# Altium schematic sheet Discrete.SchDoc
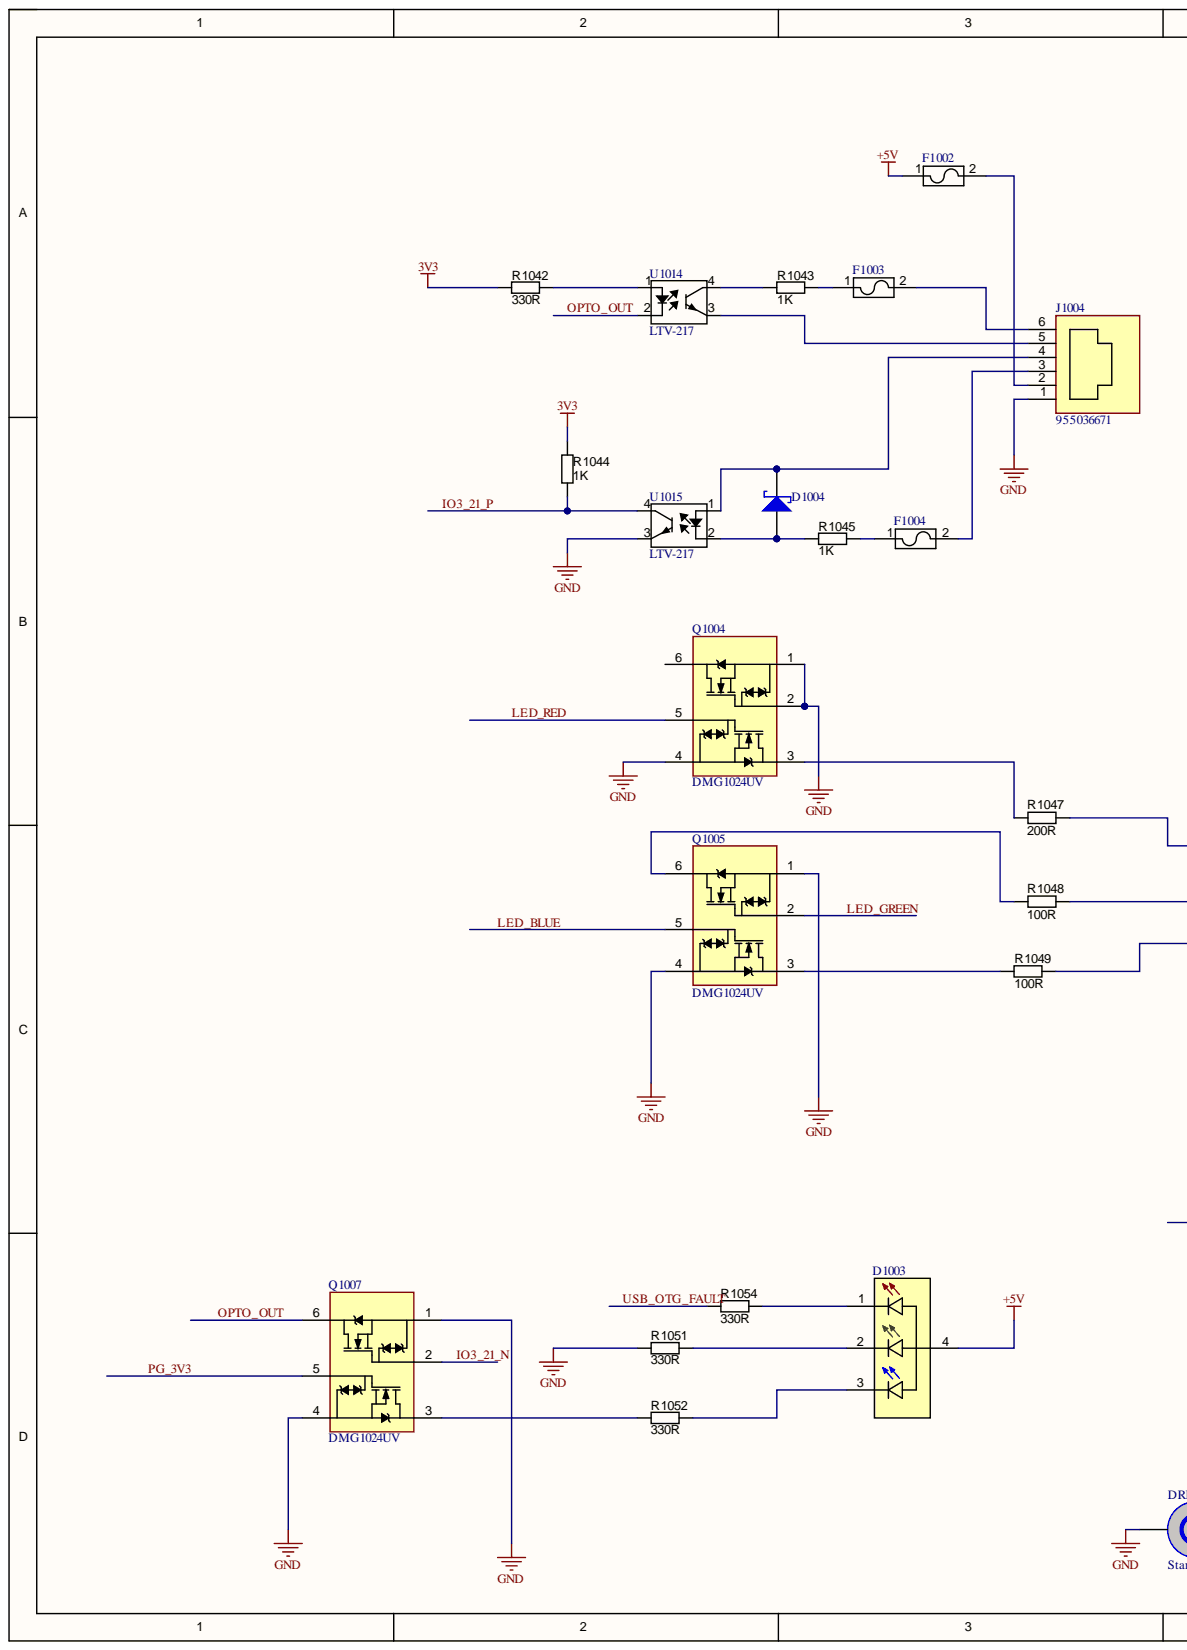
[diagram p0: Discrete.SchDoc, left]
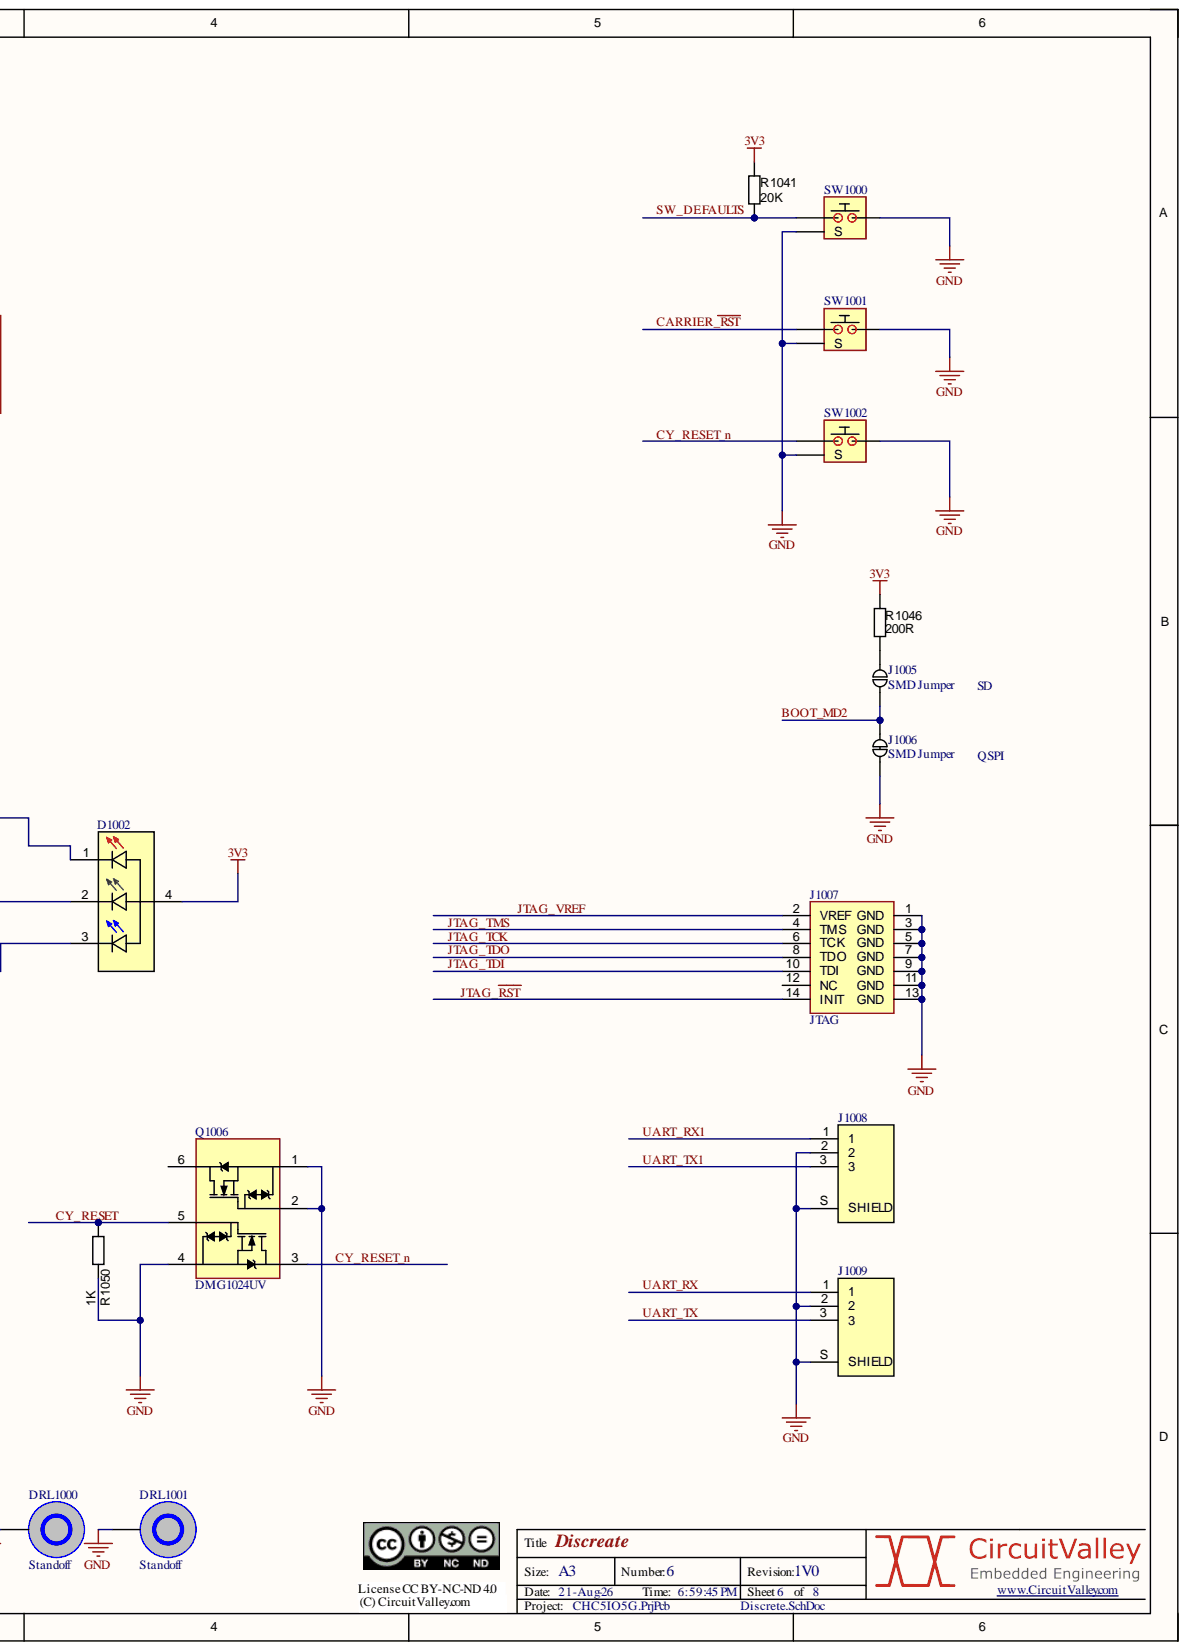
[diagram p1: Discrete.SchDoc, right]

## Parts
D1002  LTST-C19HE1WT  [0606]  (value not drawn)
D1003  LTST-C19HE1WT  [0606]  (value not drawn)
D1004  RB161QS-40  [SMD1006]  (value not drawn)
DRL1000  Standoff  [M2_STANDOFF_SMTSOM205BTR]
DRL1001  Standoff  [M2_STANDOFF_SMTSOM205BTR]
F1002  0467005.NRHF  [0467005.NRHF]  (value not drawn)
F1003  0467.500NRHF  [0467005.NRHF]  (value not drawn)
F1004  0467.500NRHF  [0467005.NRHF]  (value not drawn)
J1004  955036671  [955036671]
J1005  SMD Jumper  [SMD_Jumper]  (no BOM)
J1006  SMD Jumper  [SMD_Jumper_bridge]
J1007  JTAG  [878321420]
J1008  BM03B-SRSS-TB(LF)(SN)  [BM03B-SRSS-TB(LF)(SN)]  (value not drawn)
J1009  BM03B-SRSS-TB(LF)(SN)  [BM03B-SRSS-TB(LF)(SN)]  (value not drawn)
Q1004  DMG1024UV  [SOT563]
Q1005  DMG1024UV  [SOT563]
Q1006  DMG1024UV  [SOT563]
Q1007  DMG1024UV  [SOT563]
R1041  value 20K  [RESC1005N]  (hidden comment: Thick Film)
R1042  value 330R  [RESC1005N]  (hidden comment: Thick Film)
R1043  value 1K  [RESC1005N]  (hidden comment: Thick Film)
R1044  value 1K  [RESC1005N]  (hidden comment: Thick Film)
R1045  value 1K  [RESC1005N]  (hidden comment: Thick Film)
R1046  value 200R  [RESC1005N]  (hidden comment: Thick Film)
R1047  value 200R  [RESC1005N]  (hidden comment: Thick Film)
R1048  value 100R  [RESC1005N]  (hidden comment: Thick Film)
R1049  value 100R  [RESC1005N]  (hidden comment: Thick Film)
R1050  value 1K  [RESC1005N]  (hidden comment: Thick Film)
R1051  value 330R  [RESC1005N]  (hidden comment: Thick Film)
R1052  value 330R  [RESC1005N]  (hidden comment: Thick Film)
R1054  value 330R  [RESC1005N]  (hidden comment: Thick Film)
SW1000  SKSGPAE010  [SKSGPAE010]  (value not drawn)
SW1001  SKSGPAE010  [SKSGPAE010]  (value not drawn)
SW1002  SKSGPAE010  [SKSGPAE010]  (value not drawn)
U1014  LTV-217  [SOP4_127]
U1015  LTV-217  [SOP4_127]

## Nets
3V3: D1002.4 R1041.2 R1042.1 R1044.2 R1046.2
+5V: D1003.4 F1002.1
GND: DRL1000.0 DRL1001.0 J1004.1 J1006.1 J1007.1(GND) J1007.3(GND) J1007.5(GND) J1007.7(GND) J1007.9(GND) J1007.11(GND) J1007.13(GND) J1008.2 J1008.S(SHIELD) J1009.2 J1009.S(SHIELD) Q1004.1 Q1004.2 Q1004.4 Q1005.1 Q1005.4 Q1006.1 Q1006.2 Q1006.4 Q1007.1 Q1007.4 R1050.2 R1051.1 SW1000.2 SW1000.3 SW1001.2 SW1001.3 SW1002.2 SW1002.3 U1015.3
BOOT_MD2: J1005.1 J1006.2
CARRIER_~{RST}: SW1001.1
CY_RESET: Q1006.5 R1050.1
CY_RESET_n: Q1006.3 SW1002.1
IO3_21_N: Q1007.2
IO3_21_P: R1044.1 U1015.4
JTAG_~{RST}: J1007.14(INIT)
JTAG_TCK: J1007.6(TCK)
JTAG_TDI: J1007.10(TDI)
JTAG_TDO: J1007.8(TDO)
JTAG_TMS: J1007.4(TMS)
JTAG_VREF: J1007.2(VREF)
LED_BLUE: Q1005.5
LED_GREEN: Q1005.2
LED_RED: Q1004.5
OPTO_OUT: Q1007.6 U1014.2
PG_3V3: Q1007.5
SW_DEFAULTS: R1041.1 SW1000.1
UART_RX: J1009.1
UART_RX1: J1008.1
UART_TX: J1009.3
UART_TX1: J1008.3
USB_OTG_FAULT: R1054.1
NetD1002_1: D1002.1 R1047.2
NetD1002_2: D1002.2 R1048.2
NetD1002_3: D1002.3 R1049.2
NetD1003_1: D1003.1 R1054.2
NetD1003_2: D1003.2 R1051.2
NetD1003_3: D1003.3 R1052.2
NetD1004_1: D1004.1 R1045.1 U1015.2
NetD1004_2: D1004.2 J1004.4 U1015.1
NetF1002_2: F1002.2 J1004.2
NetF1003_1: F1003.1 R1043.2
NetF1003_2: F1003.2 J1004.6
NetF1004_1: F1004.1 R1045.2
NetF1004_2: F1004.2 J1004.3
NetJ1004_5: J1004.5 U1014.3
NetJ1005_2: J1005.2 R1046.1
NetQ1004_3: Q1004.3 R1047.1
NetQ1005_3: Q1005.3 R1049.1
NetQ1005_6: Q1005.6 R1048.1
NetQ1007_3: Q1007.3 R1052.1
NetR1042_2: R1042.2 U1014.1
NetR1043_1: R1043.1 U1014.4

Not connected: J1007 12(NC); Q1004 6; Q1006 6

## Library data of the parts
D1002 D1003 = RGBLED: Component Kind: Standard; Description: LTST-C19HE1WT; Library Name: cvlib.SchLib
D1004 = Diode: Component Kind: Standard; Description: Diode; Library Name: cvlib.SchLib
DRL1000 DRL1001 = PCB_Sandoff: Component Kind: Standard; Library Name: cvlib.SchLib
F1002 = Fuse: Component Kind: Standard; Description: 0467005.NRHF; Library Name: cvlib.SchLib
F1003 F1004 = Fuse: 0467005.NRHF; Component Kind: Standard; Library Name: cvlib.SchLib
J1004 = RJ12: 955036671 RJ12 6 Pins; Component Kind: Standard; Library Name: cvlib.SchLib
J1005 = SMD_Jumper: Description: SMD Jumper; Library Name: cvlib.SchLib
J1006 = SMD_Jumper_Bridged: Component Kind: Net Tie; Description: SMD Jumper; Library Name: cvlib.SchLib
J1007 = Xilinx_JTAG: Component Kind: Standard; Description: JTAG; Library Name: cvlib.SchLib
R1041-R1052 R1054 = RES_3K3_0402_5%: Resistor SMD chip 3.3k Ohm 0.063W 5% 0402; Manufacturer 1: Yageo; Manufacturer Part Number 1: RC0402JR-073K3L; Ratings: 1/16 W; Component Kind: Standard; ComponentLink1Description: http://www.yageo.com/documents/recent/PYu-RC0402_51_RoHS_L_6.pdf; ComponentLink1URL: http://www.yageo.com/documents/recent/PYu-RC0402_51_RoHS_L_6.pdf; Library Name: PBL - Resistors.SCHLIB; Material: Thick Film; Package / Case: 0402 (1005 metric); Supplier 1: Digi-Key; Supplier Part Number 1: 311-3.3KJRCT-ND; Tolerance: ±5%
SW1000-SW1002 = PushButton_Grounded: Component Kind: Standard; Library Name: cvlib.SchLib
U1014 U1015 = LTV-217: Optocopler; Component Kind: Standard; Library Name: cvlib.SchLib
J1008 J1009 = BM03B-SRSS-TB(LF)(SN): Component Kind: Standard; Description: BM03B-SRSS-TB(LF)(SN); Library Name: cvlib.SchLib
Q1004-Q1007 = DMG1024UV: Component Kind: Standard; Description: DMG1024UV; Library Name: cvlib.SchLib
